# Altium schematic sheet Fan_driver.SchDoc
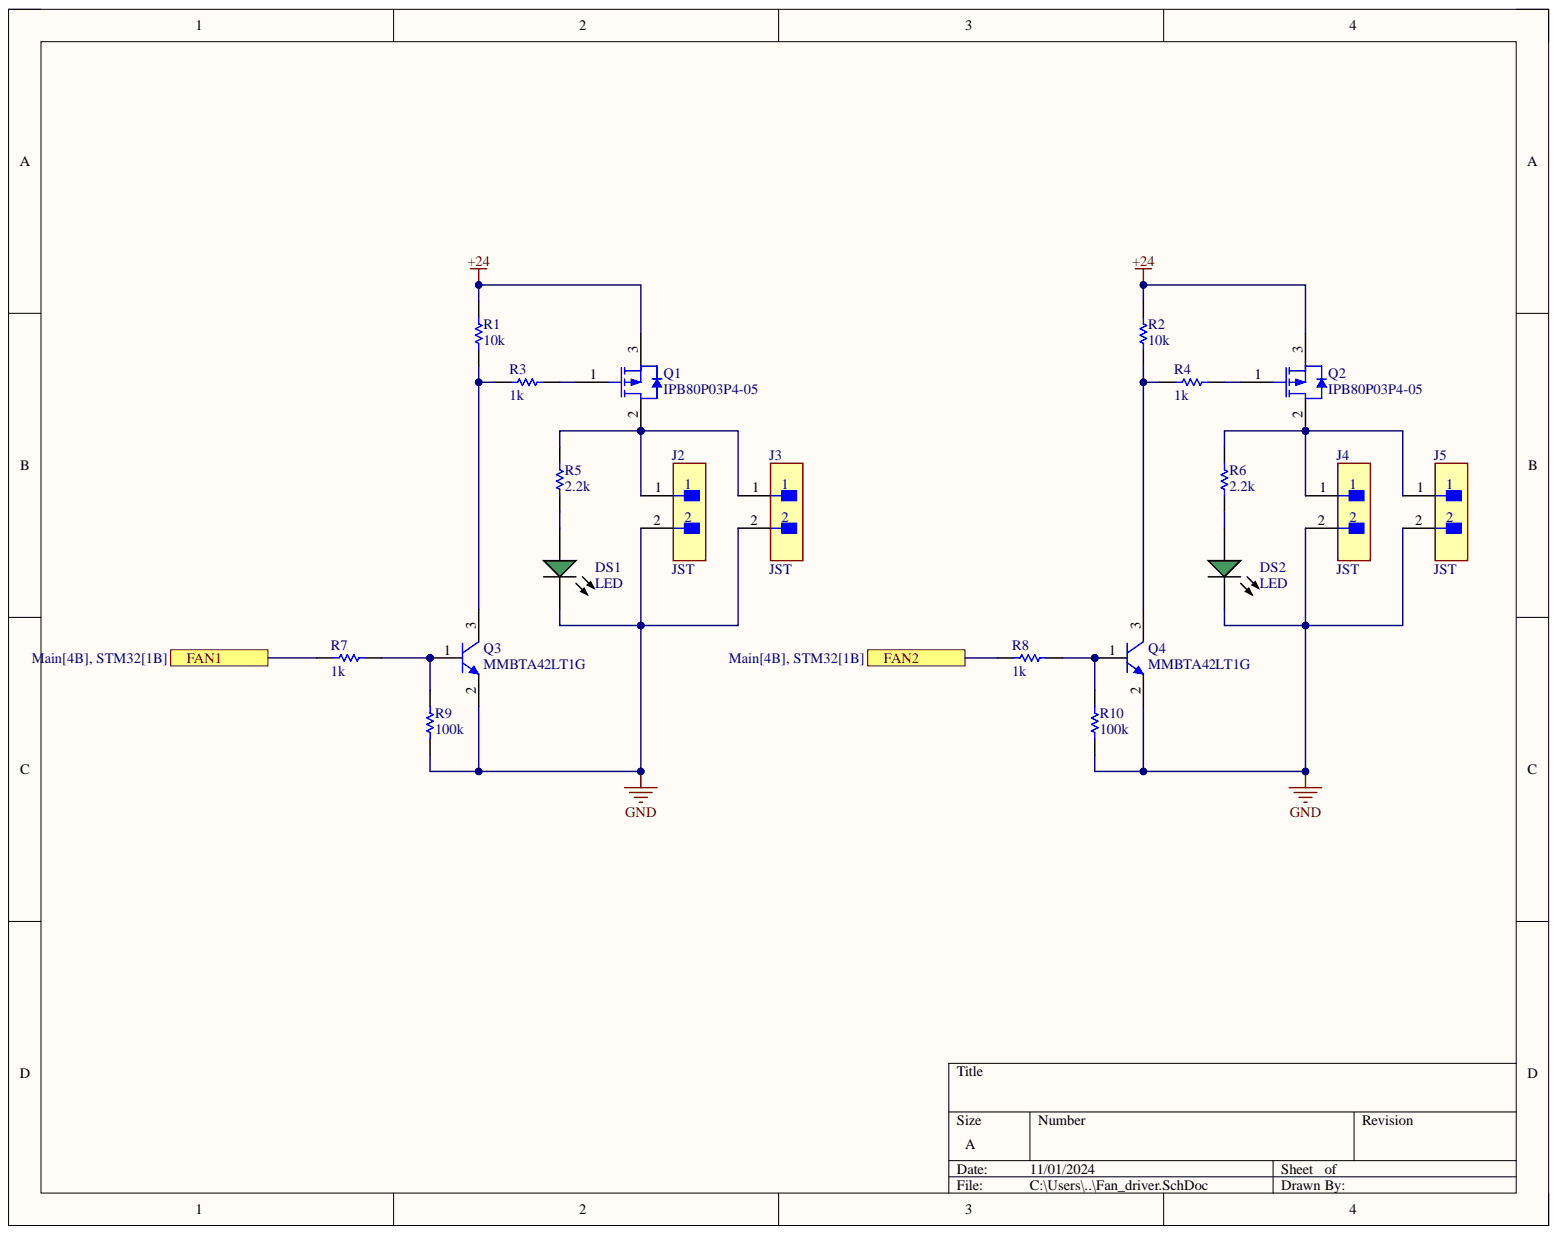
[diagram p0: Fan_driver.SchDoc]

## Parts
DS1  LED  [AVAG-HSMX-C170_V]
DS2  LED  [AVAG-HSMX-C170_V]
J2  JST  [FP-B2B-EH-A_LF_SN-MFG]
J3  JST  [FP-B2B-EH-A_LF_SN-MFG]
J4  JST  [FP-B2B-EH-A_LF_SN-MFG]
J5  JST  [FP-B2B-EH-A_LF_SN-MFG]
Q1  IPB80P03P4-05  [INF-PG-TO263-3-2_N]
Q2  IPB80P03P4-05  [INF-PG-TO263-3-2_N]
Q3  MMBTA42LT1G  [ONSC-SOT-23-3-318-08_V]
Q4  MMBTA42LT1G  [ONSC-SOT-23-3-318-08_V]
R1  10k  [RESC2013X50X30LL20T20]
R2  10k  [RESC2013X50X30LL20T20]
R3  1k  [RESC2013X50X30LL20T20]
R4  1k  [RESC2013X50X30LL20T20]
R5  2.2k  [RESC2013X50X30LL20T20]
R6  2.2k  [RESC2013X50X30LL20T20]
R7  1k  [RESC2013X50X30LL20T20]
R8  1k  [RESC2013X50X30LL20T20]
R9  100k  [RESC2013X50X30LL20T20]
R10  100k  [RESC2013X50X30LL20T20]

## Ports
FAN1, FAN2

## Nets
+24: Q1.3 Q2.3 R1.2 R2.2
GND: DS1.2 DS2.2 J2.2 J3.2 J4.2 J5.2 Q3.2 Q4.2 R9.1 R10.1
FAN1: R7.1 ; port FAN1
FAN2: R8.1 ; port FAN2
NetDS1_1: DS1.1 R5.1
NetDS2_1: DS2.1 R6.1
NetJ2_1: J2.1 J3.1 Q1.2 R5.2
NetJ4_1: J4.1 J5.1 Q2.2 R6.2
NetQ1_1: Q1.1 R3.2
NetQ2_1: Q2.1 R4.2
NetQ3_1: Q3.1 R7.2 R9.2
NetQ3_3: Q3.3 R1.1 R3.1
NetQ4_1: Q4.1 R8.2 R10.2
NetQ4_3: Q4.3 R2.1 R4.1

## Library data of the parts
DS1 DS2 = CMP-2000-05062-1: Chip LED, Green, 2.2 V, -40 to 85 degC, 2-Pin SMD, Pb-Free, Tape and Reel; Manufacturer: Avago; Bulb Size: 1.25mmx1.4mm; Dominant Wavelength: 572nm; External Depth: 0.8mm; External Length / Height: 2mm; External Width: 1.25mm; Forward Current If: 20mA; Forward Voltage: 2.2V; Forward Voltage VF Max: 2.6V; LED Type: Standard; Lens Shape: Rectangular; Light Area Height: 1mm; Luminous Intensity: 15mcd; Luminous Intensity Min: 4mcd; No. of Pins: 2; Opto Mounting Orientation: Top; Peak Wavelength: 570nm; PIV Max: 5V; SVHC: NoSVHC(16-Jun-2014); Viewing Angle: 170deg; Component Kind: Standard; Datasheet URL: https://datasheet.ciiva.com/29280/180495-da-01-en-chip-led-hsmg-c170-gelb-gruen-29280971.pdf; Datasheet Version: Oct-08; Dominant Wavelength Typ: 572nm; Forward Current If Max: 20mA; LED Colour: Green; LED Mounting: SMD; Library Name: Altium Content Vault; Luminous Intensity Typ: 15mcd; Manufacturer URL: http://www.avagotech.com/; Mounting Type: SMD; MSL: MSL2A-4weeks; Operating Temperature Max: 85degC; Operating Temperature Min: -30degC; Operating Temperature Range: -30degCto+85degC; Opto Case Style: SMD; Package Description: 2-Pin Surface Mount Device, Body 2 x 1.25 mm, Height 0.8 mm; Package Reference: HSMX-C170; Packaging: CutTape; Peak Forward Current: 20mA; Storage Temperature Max: 85degC; Storage Temperature Min: -40degC; Voltage Vf Typ: 2.2V; Wavelength Typ: 570nm
J2-J5 = CMP-17439-000026-1: CONN HEADER VERT 2POS 2.5MM; Connector Type: Plug; Contact Resistance: 20mR; Current Rating: 3A; Gender: Male; Max Voltage Rating (AC): 250V; Number of Contacts: 2; Color: Natural; Component Kind: Standard; Contact Material: Copper Alloy; Contact Mating Length: 0.13inch; Contact Plating: Tin; Contact Type: Male Pin; Depth: 3.8mm; Fastening Type: Detent; Housing Color: White; Housing Material: Nylon; Lead Free: Lead Free; Length: 7.5mm; Library Name: Altium Content Vault; Max Current Rating: 3A; Max Operating Temperature: 85°C; Min Operating Temperature: -25°C; Mount: Through Hole; Number of Positions: 2; Number of Rows: 1; Orientation: Straight; Packaging: Bulk; Pitch: 2.5mm; Series: EH; Termination: Press-Fit; Voltage Rating: 250V
Q1 Q2 = CMP-1138-00228-1: OptiMOS-P2 Power-Transistor, -30 V VDS, -80 A ID, PG-TO263-3-2, Reel, Green; Manufacturer: Infineon; Green: TRUE; ID (max): -80 A; IDpuls (max): -320 A; Ptot (max): 137 W; QG: 100 nC; RDS (on) (max) (@10V): 4.7 mOhm; RthJC (max): 1.1 K/W; VDS (max): -30 V; VGS(th) (max): -4 V; VGS(th) (min): -2 V; Component Kind: Standard; ComponentLink1Description: Manufacturer URL; ComponentLink1URL: http://www.infineon.com/; ComponentLink2Description: Datasheet; ComponentLink2URL: http://www.infineon.com/dgdl/IPP_B_I80P03P4-05_DS_10.pdf?folderId=db3a304314dca3890114ef902baa05f9&fileId=db3a30431ddc9372011e07ef59f52807&location=en.Products.Automotive_ICs.Automotive_MOSFETs.Single__P-Channel_30V_MOSFETs.DATASHEET.IPP_B_I80P03P4-05_DS_1; ComponentLink3Description: Package Specification; ComponentLink3URL: http://www.infineon.com/dgdl/BTS141TC_DS_10.pdf?folderId=db3a3043163797a6011667aa084c0e01&fileId=db3a3043242ebc9e01242f43f58b003e&location=en.Products.Automotive_ICs.Smart_High-_and_Low-Side_Switches.HITFET___TEMPFET__-_Smart_Low-Side_Switches.DATASHEET.BT; DatasheetVersion: Rev. 1.0; Halogen-free: TRUE; Library Name: Altium Content Vault; Mounting Technology: Surface Mount; PackageDescription: 3-Pin Plastic (Green) Transistor Single Outline Package, 10 x 9.25 mm Body, 2.54 mm Pitch; PackageReference: PG-TO263-3-2; PackageVersion: Jul-09; Packing: Reel; PartNumber: IPB80P03P4-05; Technology: OptiMOS-P2
Q3 Q4 = CMP-1048-00662-1: High Voltage Transistor, NPN Silicon, 3-Pin SOT-23, Pb-Free, Tape and Reel; Manufacturer: On Semiconductor; fT Min (MHz): 50; IC Continuous (A): 0.5; Component Kind: Standard; ComponentLink1Description: Manufacturer URL; ComponentLink1URL: http://www.onsemi.com/; ComponentLink2Description: Datasheet; ComponentLink2URL: http://www.onsemi.cn/pub_link/Collateral/MMBTA42LT1-D.PDF; ComponentLink3Description: Package Specification; ComponentLink3URL: http://www.onsemi.cn/pub_link/Collateral/318-08.PDF; DatasheetVersion: Rev. 12; Library Name: Altium Content Vault; Mounting Technology: Surface Mount; PackageDescription: 3-Pin Small Outline Transistor, Body 2.92 x 1.3 mm, Pitch 0.95 mm; PackageReference: SOT-23-3-318-08; PackageVersion: Rev. AP, 11/2009; Packing: Tape and Reel; PartNumber: MMBTA42LT1G; Polarity: NPN; RoHS: TRUE
R1-R10 = CMP-2001-04896-1: Manufacturer: Vishay Dale; Part Number: CRCW08050000Z0EA; Component Kind: Standard; Library Name: Altium Content Vault
